# Altium schematic sheet TSAL 2024-2025.SchDoc
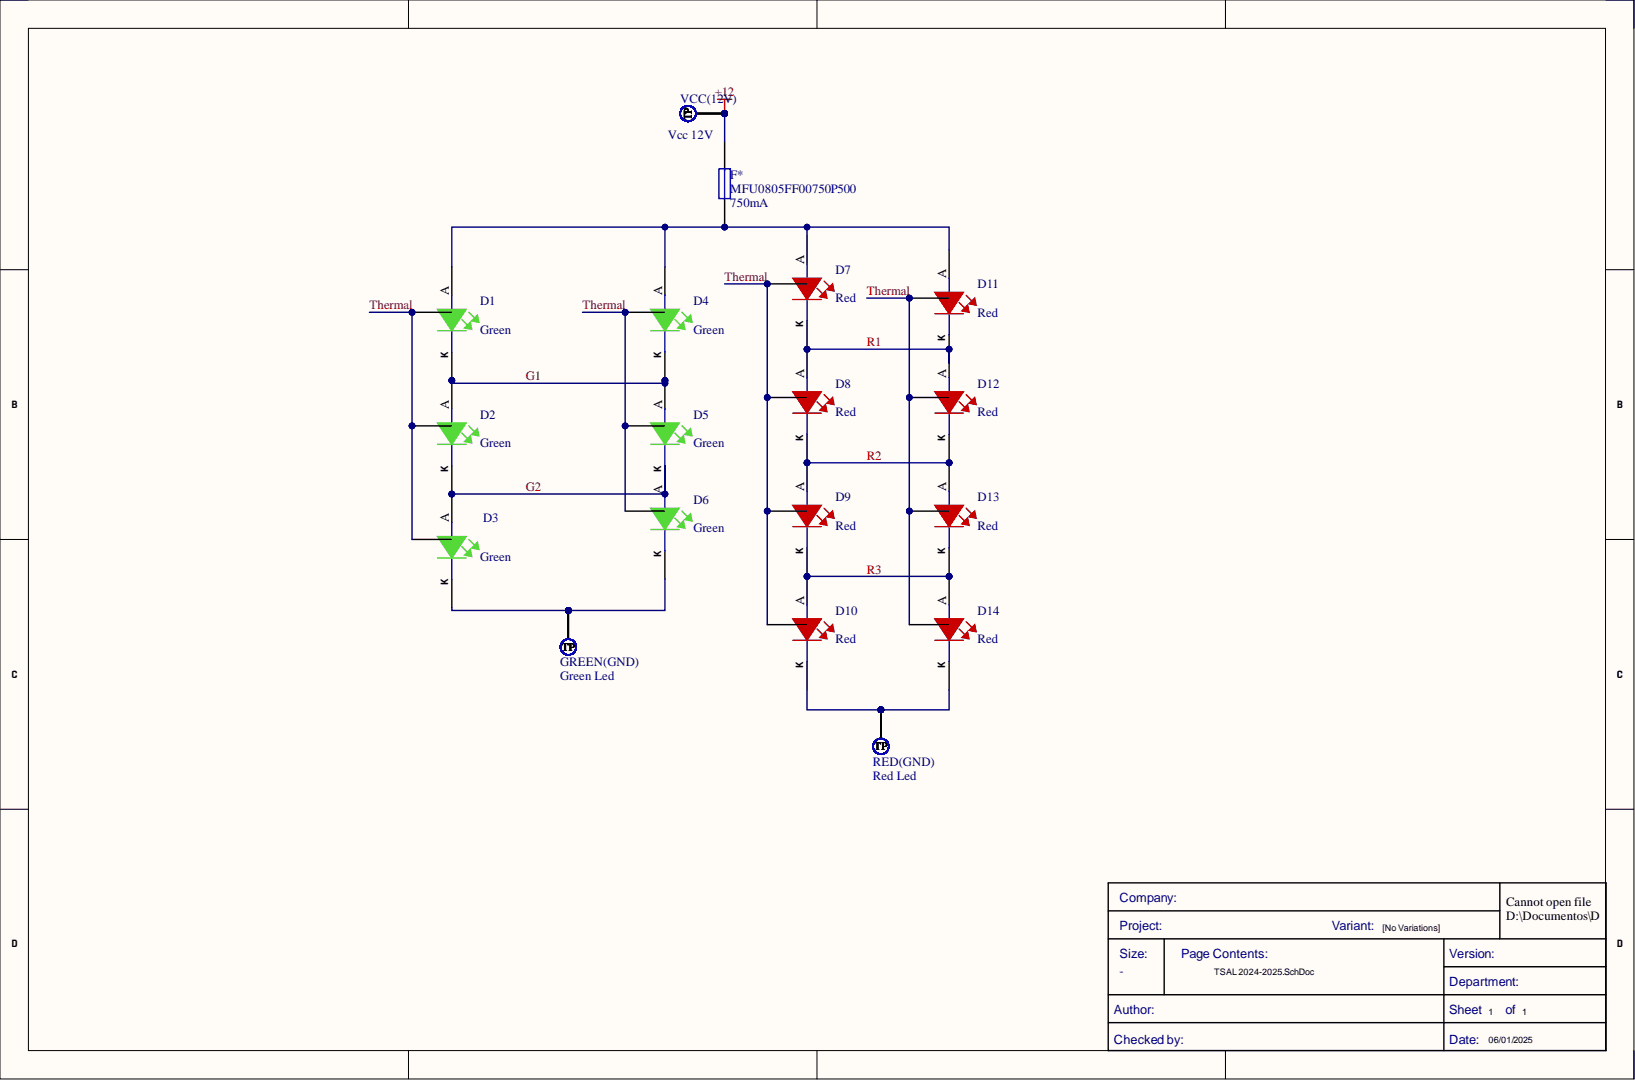
[diagram p0: TSAL 2024-2025.SchDoc]

## Parts
D1  Color: Green  [LED_3535_WE - duplicate]
D2  Color: Green  [LED_3535_WE - duplicate]
D3  Color: Green  [LED_3535_WE - duplicate]
D4  Color: Green  [LED_3535_WE - duplicate]  (hidden comment: LEDGS-3535-85_DUPLICATE)
D5  Color: Green  [LED_3535_WE - duplicate]  (hidden comment: LEDGS-3535-85_DUPLICATE)
D6  Color: Green  [LED_3535_WE - duplicate]  (hidden comment: LEDGS-3535-85_DUPLICATE)
D7  Color: Red  [LED_3535_WE - duplicate]  (hidden comment: LEDRS-3535-55_DUPLICATE)
D8  Color: Red  [LED_3535_WE - duplicate]  (hidden comment: LEDRS-3535-55_DUPLICATE)
D9  Color: Red  [LED_3535_WE - duplicate]  (hidden comment: LEDRS-3535-55_DUPLICATE)
D10  Color: Red  [LED_3535_WE - duplicate]  (hidden comment: LEDRS-3535-55_DUPLICATE)
D11  Color: Red  [LED_3535_WE - duplicate]  (hidden comment: LEDRS-3535-55_DUPLICATE)
D12  Color: Red  [LED_3535_WE - duplicate]  (hidden comment: LEDRS-3535-55_DUPLICATE)
D13  Color: Red  [LED_3535_WE - duplicate]  (hidden comment: LEDRS-3535-55_DUPLICATE)
D14  Color: Red  [LED_3535_WE - duplicate]  (hidden comment: LEDRS-3535-55_DUPLICATE)
F*  MFU0805FF00750P500  Current Rating: 750mA  [0805]
GREEN(GND)  Green Led  [TestPoint]  (no BOM)
RED(GND)  Red Led  [TestPoint]  (no BOM)
VCC(12V)  Vcc 12V  [TestPoint]  (no BOM)

## Nets
+12: F*.2 VCC(12V).1
G1: D1.K D2.A D4.K D5.A
G2: D2.K D3.A D5.K D6.A
R1: D7.K D8.A D11.K D12.A
R2: D8.K D9.A D12.K D13.A
R3: D9.K D10.A D13.K D14.A
Thermal: D1.17 D2.17 D3.17 D4.17 D5.17 D6.17 D7.17 D8.17 D9.17 D10.17 D11.17 D12.17 D13.17 D14.17
NetD1_A: D1.A D4.A D7.A D11.A F*.1
NetD3_K: D3.K D6.K GREEN(GND).1
NetD10_K: D10.K D14.K RED(GND).1

## Library data of the parts
D1-D6 = CMP-00150-1: High Brightness LED, WL-SMDC Series, 525 nm, Green, 85 lm, 350 mA; Manufacturer: Wurth Electronics; Manufacturer Part Number: 150353GS74500; .Creator: GP; Forward Current: 1A; Forward Voltage: 3.4V; Luminous Flux: 85lm; Test Current: 350mA; Test Temperature: 25°C; Thermal Resistance of Package: 8°C/W; Viewing Angle: 125°; Wavelength: 525nm; Case/Package: SMD/SMT; Component Kind: Standard; ComponentLink1Description: http://www.farnell.com/datasheets/1886832.pdf?src-supplier=Farnell; ComponentLink1URL: http://www.farnell.com/datasheets/1886832.pdf?src-supplier=Farnell; ComponentLink2Description: http://www.farnell.com/datasheets/1886832.pdf?src-supplier=Element14; ComponentLink2URL: http://www.farnell.com/datasheets/1886832.pdf?src-supplier=Element14; ComponentLink3Description: Where buy it; ComponentLink3URL: https://www.we-online.com/catalog/en/WL-SMDC?sq=150353rs74500&sp=https%3A%2F%2Fwww.we-online.com%2Fweb%2Fen%2Fwuerth_elektronik%2Fsearchpage.php%3Fsearch%3D150353rs74500#150353GS74500; Design Item ID: LEDGS-3535-85_DUPLICATE; Max Current Rating: 1A; Mount: Surface Mount; Packaging: Cut Tape; Pricing 1: 1=3,24000, 50=2,85700, 100=2,34700, 500=2,08000 (USD); REACH SVHC: Unknown; RoHS: Yes; RoHS Compliant: Yes; Source: Semiconductor.SchLib; Library Name: EMEA- E-Tech Racing- I'Escola d'Enginyeria de Barcelona Est
D7-D14 = CMP-00152-1: High Brightness Led, Wl-Smdc Series, 625 Nm, Red, 55 Lm, 350 Ma; Manufacturer: Wurth Electronics; Manufacturer Part Number: 150353RS74500; .Creator: GP; Forward Current: 700mA; Forward Voltage: 2.2V; Luminous Flux: 55lm; Test Current: 350mA; Test Temperature: 25°C; Thermal Resistance of Package: 10°C/W; Viewing Angle: 125°; Wavelength: 625nm; Case/Package: SMD/SMT; Component Kind: Standard; ComponentLink1Description: http://www.farnell.com/datasheets/1886833.pdf?src-supplier=Farnell; ComponentLink1URL: http://www.farnell.com/datasheets/1886833.pdf?src-supplier=Farnell; ComponentLink2Description: http://www.farnell.com/datasheets/1886833.pdf?src-supplier=Element14; ComponentLink2URL: http://www.farnell.com/datasheets/1886833.pdf?src-supplier=Element14; ComponentLink3Description: Where buy it; ComponentLink3URL: https://www.we-online.com/catalog/en/WL-SMDC?sq=150353rs74500&sp=https%3A%2F%2Fwww.we-online.com%2Fweb%2Fen%2Fwuerth_elektronik%2Fsearchpage.php%3Fsearch%3D150353rs74500#150353RS74500; Design Item ID: LEDRS-3535-55_DUPLICATE; Max Current Rating: 700mA; Mount: Surface Mount; Packaging: Cut Tape; Pricing 1: 5=1,40500 (USD); REACH SVHC: Unknown; RoHS: Yes; RoHS Compliant: Yes; Source: Semiconductor.SchLib; Stock 1: 1; Library Name: EMEA- E-Tech Racing- I'Escola d'Enginyeria de Barcelona Est
F* = CMP-005-00000-3: Electric Fuse, Very Fast Blow, 0.75A, 32VDC, 50A (IR), Surface Mount; Component Kind: Standard; Max Operating Temperature: 125°C; Min Operating Temperature: -55°C; Mounting Technology: Surface Mount; RoHS Compliant: Yes; Library Name: EMEA- E-Tech Racing- I'Escola d'Enginyeria de Barcelona Est
GREEN(GND) RED(GND) VCC(12V) = CMP-00042-2: Test Point 1x1 mm; .Creator: GP; Category: TestPoint; Design Item ID: TestPoint; Source: e-Tech_Racing.SchLib; .PCBcheck: GP; .SCHcheck: GP; Library Name: EMEA- E-Tech Racing- I'Escola d'Enginyeria de Barcelona Est
